# Altium schematic sheet Sheet1.SchDoc(Sheet1)
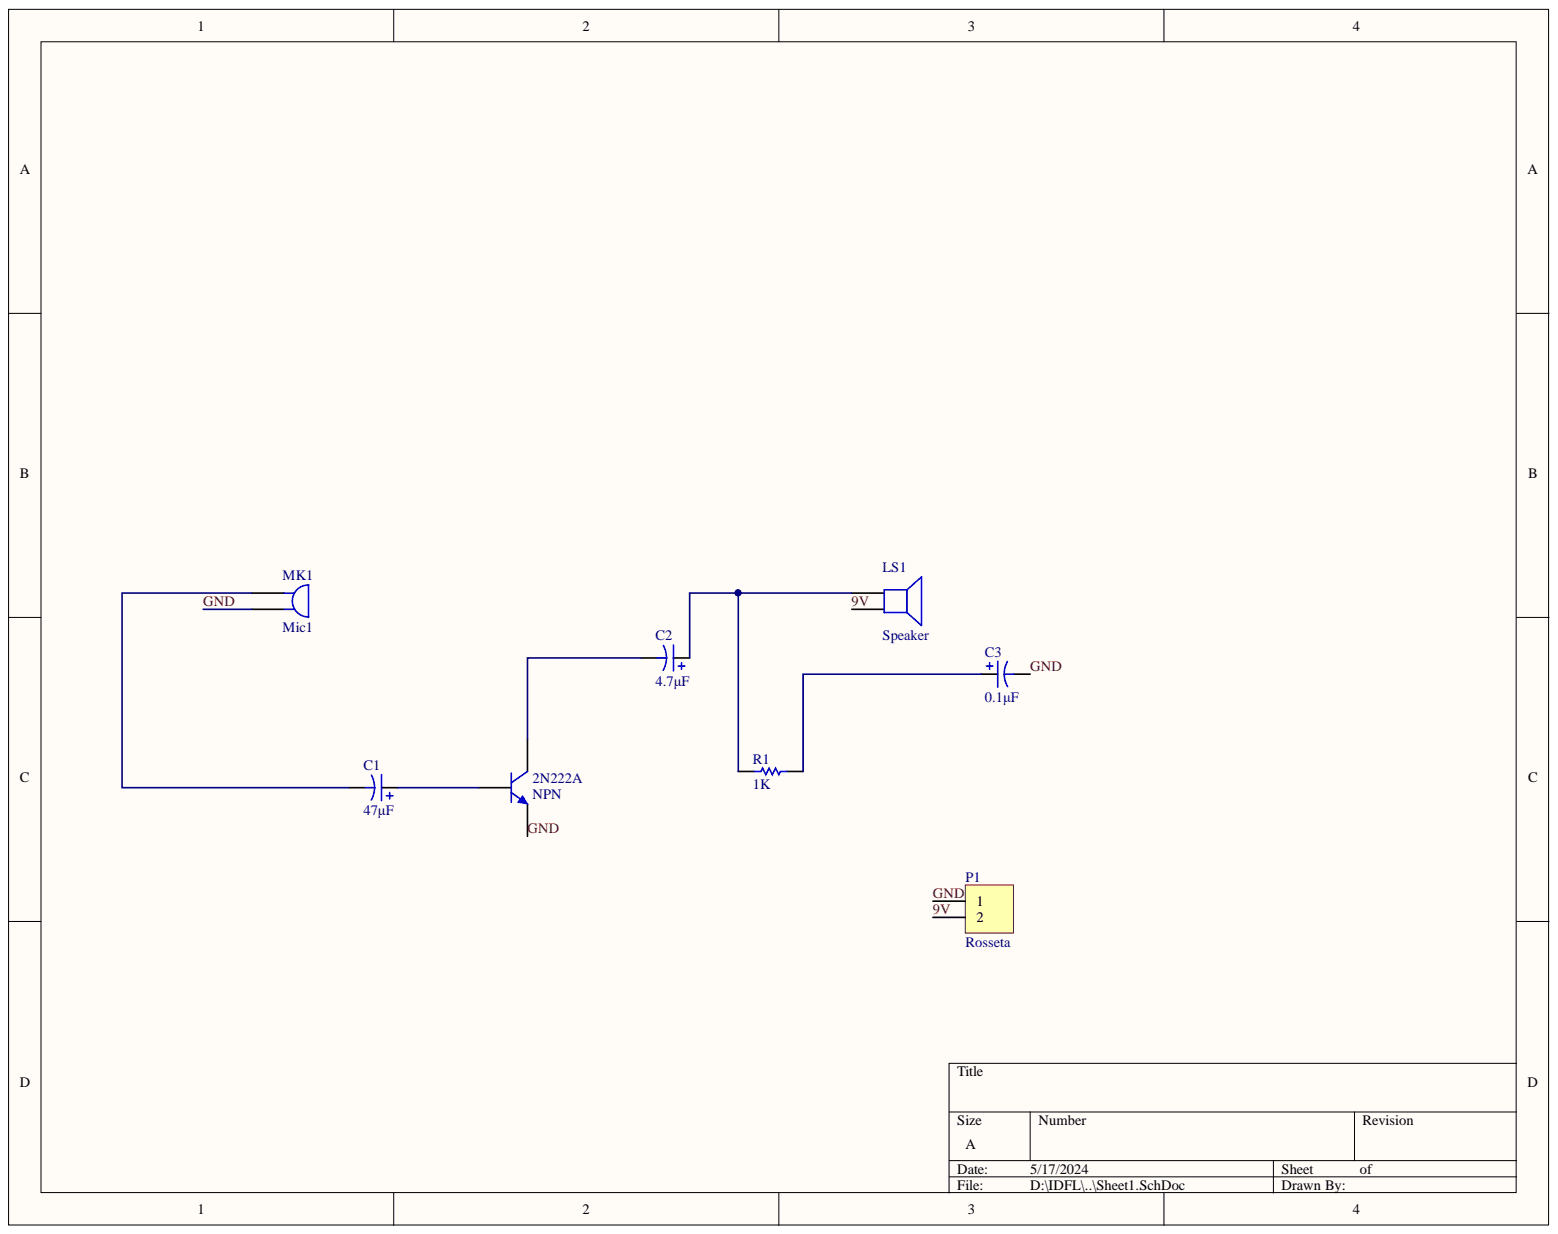
[diagram p0: Sheet1.SchDoc]

## Parts
2N222A  NPN  [TO-226-AA]
C1  47μF  [RB7.6-15]
C2  4.7μF  [RB7.6-15]
C3  0.1μF  [RB7.6-15]
LS1  Speaker  [PIN2]
MK1  Mic1  [PIN2]
P1  Rosseta  [HDR1X2]
R1  1K  [AXIAL-0.3]

## Nets
9V: LS1.2 P1.2
GND: 2N222A.3 C3.2 MK1.2 P1.1
Net2N222A_1: 2N222A.1 C2.2
Net2N222A_2: 2N222A.2 C1.1
NetC1_2: C1.2 MK1.1
NetC2_1: C2.1 LS1.1 R1.1
NetC3_1: C3.1 R1.2

## Library data of the parts
2N222A = NPN: NPN Bipolar Transistor; Simulation: NPN; Signal Integrity: NPN; Published: 8-Jun-2000; PackageReference: TO-226-AA; PackageDescription: TO, Flat Index; 3 In-Line, Axial Leads; Body Dia. 4.8mm; Leads 0.55 x 0.51 mm (max); Library Name: Miscellaneous Devices.IntLib; LatestRevisionNote: Re-released for DXP Platform.; LatestRevisionDate: 17-Jul-2002; Component Kind: Standard; Code_JEDEC: TO-226-AA; Publisher: Altium Limited
C1-C3 = Cap Pol1: Polarized Capacitor (Radial); Value: 100pF; Simulation: CAP; Signal Integrity: Cap Pol; Published: 8-Jun-2000; PackageReference: RB7.6-15; PackageDescription: Polarized Capacitor (Radial); 2 Leads; Library Name: Miscellaneous Devices.IntLib; LatestRevisionNote: Re-released for DXP Platform.; LatestRevisionDate: 17-Jul-2002; Component Kind: Standard; Publisher: Altium Limited
LS1 = Speaker: Loudspeaker; Published: 24-Mar-1999; PackageReference: PIN2; Library Name: Miscellaneous Devices.IntLib; LatestRevisionNote: Re-released for DXP Platform.; LatestRevisionDate: 17-Jul-2002; Component Kind: Standard; Publisher: Altium Limited
MK1 = Mic1: Microphone; Published: 24-Mar-1999; PackageReference: PIN2; Library Name: Miscellaneous Devices.IntLib; LatestRevisionNote: Re-released for DXP Platform.; LatestRevisionDate: 17-Jul-2002; Component Kind: Standard; Publisher: Altium Limited
P1 = Header 2: Header, 2-Pin; Signal Integrity: Connector; Library Name: Miscellaneous Connectors.IntLib; LatestRevisionNote: Re-released for DXP Platform.; LatestRevisionDate: 17-Jul-2002; Component Kind: Standard; Publisher: Altium Limited
R1 = Res1: Resistor; Value: 1K; Simulation: RESISTOR; Signal Integrity: Res; Published: 8-Jun-2000; PackageReference: AXIAL-0.3; PackageDescription: Axial Device, Thru-Hole; 2 Leads; 0.3 in Pin Spacing; Library Name: Miscellaneous Devices.IntLib; LatestRevisionNote: Re-released for DXP Platform.; LatestRevisionDate: 17-Jul-2002; Component Kind: Standard; Publisher: Altium Limited
